# Altium schematic sheet Interface.SchDoc
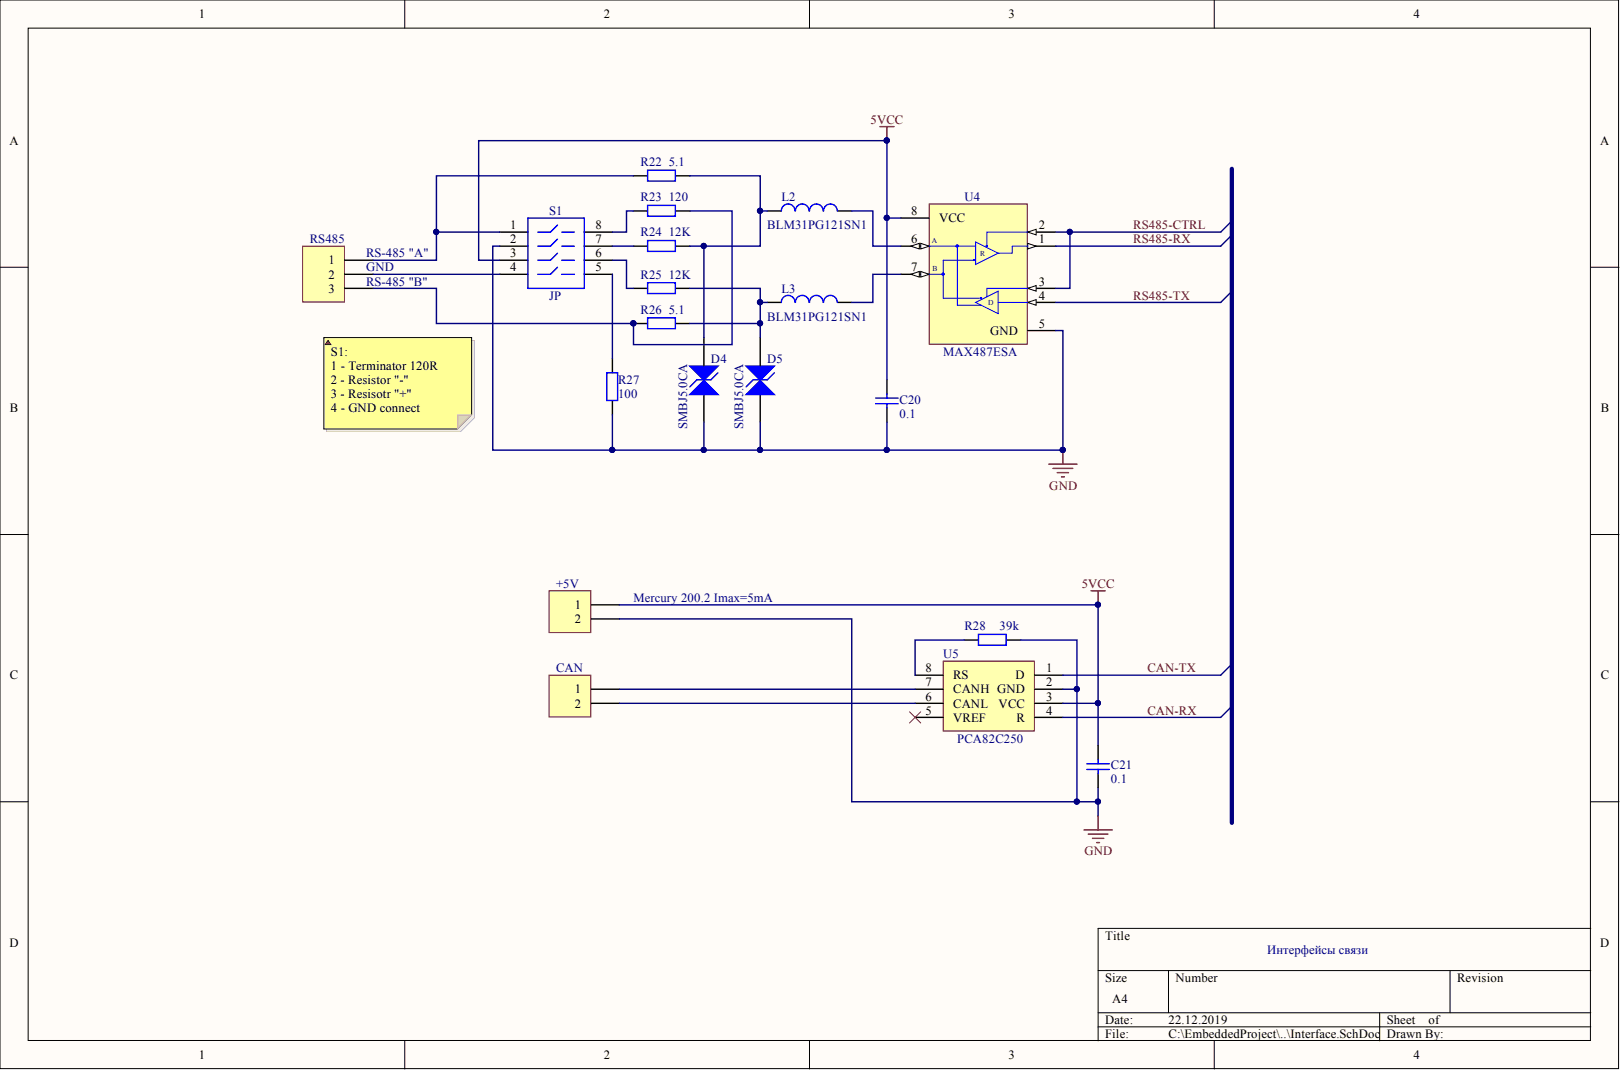
[diagram p0: Interface.SchDoc]

## Parts
+5V  Header 2  [308-021-14 (DG308-2.54-02P)]  (value not drawn)
C20  value 0.1  [C1206]  (hidden comment: Cap)
C21  value 0.1  [C1206]  (hidden comment: Cap)
CAN  Header 2  [308-021-14 (DG308-2.54-02P)]  (value not drawn)
D4  value SMBJ5.0CA  [DO-214AA]  (hidden comment: SMBJ6.0CA)
D5  value SMBJ5.0CA  [DO-214AA]  (hidden comment: SMBJ6.0CA)
L2  value BLM31PG121SN1  [L1206]  (hidden comment: Ferrite Chip Beads)
L3  value BLM31PG121SN1  [L1206]  (hidden comment: Ferrite Chip Beads)
R22  value 5.1  [R1206]  (hidden comment: Res2)
R23  value 120  [R1206]  (hidden comment: Res2)
R24  value 12K  [R1206]  (hidden comment: Res2)
R25  value 12K  [R1206]  (hidden comment: Res2)
R26  value 5.1  [R1206]  (hidden comment: Res2)
R27  value 100  [R1206]  (hidden comment: Res2)
R28  value 39k  [R1206]  (hidden comment: Res2)
RS485  Header 3  [308-031-14 (DG308-2.54-03P)]  (value not drawn)
S1  JP  [HDR2X4-1-4/5-8]
U4  value MAX487ESA  [SO8_L]  (hidden comment: Low-Power, Slew-Rate-Limited RS-485/RS-422 Transceiver)
U5  value PCA82C250  [8 pin SOIC_L]  (hidden comment: CAN transiver)

## Nets
5VCC: +5V.1 C20.1 C21.1 S1.3 U4.8(VCC) U5.3(VCC)
GND: +5V.2 C20.2 C21.2 D4.1 D5.1 R27.1 R28.1 S1.2 U4.5(GND) U5.2(GND)
CAN-RX: U5.4(R)
CAN-TX: U5.1(D)
RS485-CTRL: U4.2 U4.3
RS485-RX: U4.1
RS485-TX: U4.4
NetCAN_1: CAN.1 U5.7(CANH)
NetCAN_2: CAN.2 U5.6(CANL)
NetD4_2: D4.2 L2.1 R22.1 R24.1
NetD5_2: D5.2 L3.1 R25.1 R26.1
NetL2_2: L2.2 U4.6
NetL3_2: L3.2 U4.7
NetR22_2: R22.2 RS485.1 S1.1
NetR23_1: R23.1 S1.8
NetR23_2: R23.2 R26.2 RS485.3
NetR24_2: R24.2 S1.7
NetR25_2: R25.2 S1.6
NetR27_2: R27.2 S1.5
NetR28_2: R28.2 U5.8(RS)
NetRS485_2: RS485.2 S1.4
N00074:

Not connected: U5 5(VREF)

## Library data of the parts
+5V CAN = Header 2: Header, 2-Pin; Value: WF2; Component Kind: Standard; LatestRevisionDate: 17-Jul-2002; LatestRevisionNote: Re-released for DXP Platform.; Library Name: Miscellaneous Connectors.IntLib; Signal Integrity: Connector; Publisher: Altium Limited
C20 C21 = Cap: Capacitor; Component Kind: Standard; LatestRevisionDate: 17-Jul-2002; LatestRevisionNote: Re-released for DXP Platform.; Library Name: Miscellaneous Devices.IntLib; PackageReference: RAD-0.3; Published: 8-Jun-2000; Signal Integrity: Cap; Simulation: CAP; Publisher: Altium Limited
D4 = SMBJ12CA: Voltage suppressor; Component Kind: Standard; Library Name: Schlib1.SchLib
D5 = SMBJ6.0CA: Voltage suppressor; Component Kind: Standard; Library Name: Schlib1.SchLib
L2 L3 = Inductor: Code_IEC: 1005; Code_JEITA: 0402; Component Kind: Standard; Description: Ferrite Chip Beads; LatestRevisionDate: 29-May-2009; LatestRevisionNote: IPC-7351 Footprint Added.; Library Name: Miscellaneous Devices.IntLib; PackageDescription: Chip Inductor; PackageReference: 0402-A; Published: 8-Jun-2000; Signal Integrity: Inductor; Simulation: INDUCTOR; Publisher: Altium Limited
R22-R28 = Res2: Resistor; Component Kind: Standard; LatestRevisionDate: 17-Jul-2002; LatestRevisionNote: Re-released for DXP Platform.; Library Name: Miscellaneous Devices.IntLib; PackageReference: AXIAL-0.4; Published: 8-Jun-2000; Signal Integrity: Res; Simulation: RESISTOR; Publisher: Altium Limited
RS485 = Header 3: Header, 3-Pin; Value: WF3; Component Kind: Standard; LatestRevisionDate: 17-Jul-2002; LatestRevisionNote: Re-released for DXP Platform.; Library Name: Miscellaneous Connectors.IntLib; Signal Integrity: Connector; Publisher: Altium Limited
S1 = SW-DIP4: DIP Switch; Component Kind: Standard; LatestRevisionDate: 17-Jul-2002; LatestRevisionNote: Re-released for DXP Platform.; Library Name: Miscellaneous Devices.IntLib; PackageReference: DIP-8; Published: 24-Mar-1999; Simulation: dpsw4; value: DIP Switch; Publisher: Altium Limited
U4 = MAX487CPA: Code_JEDEC: MS-001-AB; Component Kind: Standard; ComponentLink1Description: Manufacturer Link; ComponentLink1URL: http://www.maxim-ic.com/; ComponentLink2Description: Datasheet; ComponentLink2URL: http://pdfserv.maxim-ic.com/en/ds/MAX1487-MAX491.pdf; DatasheetVersion: Feb-1996; Description: Low-Power, Slew-Rate-Limited RS-485/RS-422 Transceiver; LatestRevisionDate: 29-May-2009; LatestRevisionNote: Component Body Added.; Library Name: Maxim Communication Transceiver.IntLib; PackageDescription: DIP, 8-Pin, Row Spacing 7.62 mm, Pitch 2.54 mm; PackageReference: PA8; PackageVersion: RevB, 21-0043; PCB3D: PA8; Published: 16-Jan-2001; Publisher: Altium Limited
U5 = SN65HVD230: Component Kind: Standard; Description: CAN transiver; Library Name: Schlib1.SchLib
